# Altium schematic sheet AUDINT003_RevA_MCU.SchDoc(AUDINT003_RevA_MCU)
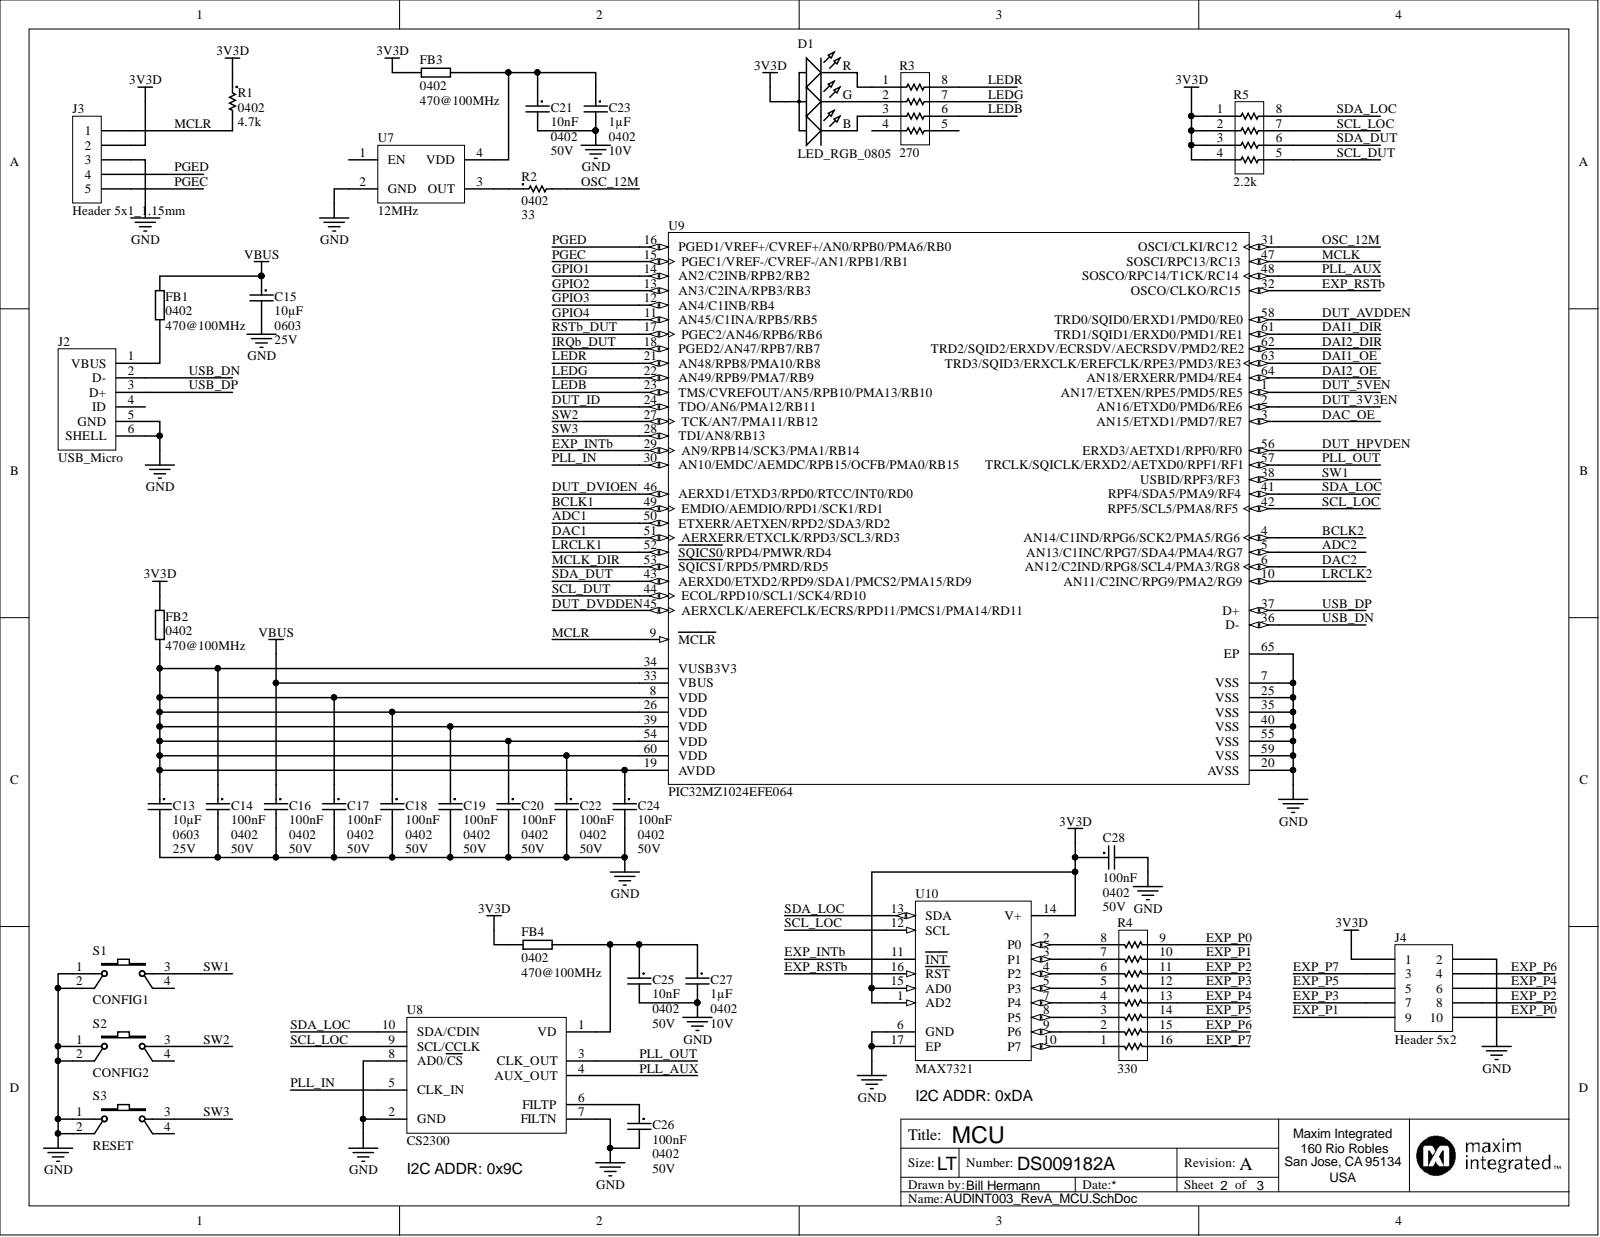
[diagram p0: AUDINT003_RevA_MCU.SchDoc]

## Parts
C13  value 10µF  Voltage: 25V  Package: 0603  [CAPC0603(1608)55_N]  (hidden comment: Capacitor)
C14  value 100nF  Voltage: 50V  Package: 0402  [CAPC0402(1005)60_L]  (hidden comment: Capacitor)
C15  value 10µF  Voltage: 25V  Package: 0603  [CAPC0603(1608)55_N]  (hidden comment: Capacitor)
C16  value 100nF  Voltage: 50V  Package: 0402  [CAPC0402(1005)60_L]  (hidden comment: Capacitor)
C17  value 100nF  Voltage: 50V  Package: 0402  [CAPC0402(1005)60_L]  (hidden comment: Capacitor)
C18  value 100nF  Voltage: 50V  Package: 0402  [CAPC0402(1005)60_L]  (hidden comment: Capacitor)
C19  value 100nF  Voltage: 50V  Package: 0402  [CAPC0402(1005)60_L]  (hidden comment: Capacitor)
C20  value 100nF  Voltage: 50V  Package: 0402  [CAPC0402(1005)60_L]  (hidden comment: Capacitor)
C21  value 10nF  Voltage: 50V  Package: 0402  [CAPC0402(1005)60_L]  (hidden comment: Capacitor)
C22  value 100nF  Voltage: 50V  Package: 0402  [CAPC0402(1005)60_L]  (hidden comment: Capacitor)
C23  value 1µF  Voltage: 10V  Package: 0402  [CAPC0402(1005)60_L]  (hidden comment: Capacitor)
C24  value 100nF  Voltage: 50V  Package: 0402  [CAPC0402(1005)60_L]  (hidden comment: Capacitor)
C25  value 10nF  Voltage: 50V  Package: 0402  [CAPC0402(1005)60_L]  (hidden comment: Capacitor)
C26  value 100nF  Voltage: 50V  Package: 0402  [CAPC0402(1005)60_L]  (hidden comment: Capacitor)
C27  value 1µF  Voltage: 10V  Package: 0402  [CAPC0402(1005)60_L]  (hidden comment: Capacitor)
C28  value 100nF  Package: 0402  [CAPC0402(1005)60_L]  (hidden comment: Capacitor)
D1  LED_RGB_0805  [LED_RGB_0805]
FB1  value 470@100MHz  Package: 0402  [RESC0402(1005)_N]  (hidden comment: BEAD1)
FB2  value 470@100MHz  Package: 0402  [RESC0402(1005)_N]  (hidden comment: BEAD1)
FB3  value 470@100MHz  Package: 0402  [RESC0402(1005)_N]  (hidden comment: BEAD1)
FB4  value 470@100MHz  Package: 0402  [RESC0402(1005)_N]  (hidden comment: BEAD1)
J2  USB_Micro  [10118192-0001LF]
J3  Header 5x1_1.15mm  [MOLEX_53047-0510]
J4  Header 5x2  [HEADER_5x2_POS_100MIL]
R1  value 4.7k  Package: 0402  [RESC0402(1005)_L]  (hidden comment: Res / 4.7kΩ / 1% / 0402)
R2  value 33  Package: 0402  [RESC0402(1005)_L]  (hidden comment: Res / 33Ω / 1% / 0402)
R3  value 270  [741X083]  (hidden comment: RES_270_ARRAY4)
R4  value 330  [741X163]  (hidden comment: RES_330_ARRAY8)
R5  value 2.2k  [741X083]  (hidden comment: RES_2k2_ARRAY4)
S1  CONFIG1  [PTS810_TACTSWITCH]
S2  CONFIG2  [PTS810_TACTSWITCH]
S3  RESET  [PTS810_TACTSWITCH]
U7  Frequency: 12MHz  [OSC_2x1p6]  (hidden comment: OSC_12M_2x1p6)
U8  CS2300  [DGS10_N]
U9  PIC32MZ1024EFE064  [MCHP-QFN-MR64-7150X7150TP_V]  (value not drawn)
U10  MAX7321  [T1633+4_N]

## Nets
3V3D: C28.1 D1.4 FB2.1 FB3.1 FB4.1 J3.2 J4.1 R1.1 R5.1 R5.2 R5.3 R5.4 U10.1(AD2) U10.14(V+) U10.15(AD0)
GND: C13.2 C14.2 C15.2 C16.2 C17.2 C18.2 C19.2 C20.2 C21.2 C22.2 C23.2 C24.2 C25.2 C26.2 C27.2 C28.2 J2.5(GND) J2.6(SHELL) J3.3 J4.2 S1.1 S1.2 S2.1 S2.2 S3.1 S3.2 U7.2(GND) U8.2(GND) U8.7(FILTN) U8.8(AD0/CS) U9.7(VSS) U9.20(AVSS) U9.25(VSS) U9.35(VSS) U9.40(VSS) U9.55(VSS) U9.59(VSS) U9.65(EP) U10.6(GND) U10.17(EP)
VBUS: C15.1 C16.1 FB1.1 U9.33(VBUS)
ADC1: U9.50(ETXERR/AETXEN/RPD2/SDA3/RD2)
ADC2: U9.5(AN13/C1INC/RPG7/SDA4/PMA4/RG7)
BCLK1: U9.49(EMDIO/AEMDIO/RPD1/SCK1/RD1)
BCLK2: U9.4(AN14/C1IND/RPG6/SCK2/PMA5/RG6)
DAC1: U9.51(AERXERR/ETXCLK/RPD3/SCL3/RD3)
DAC2: U9.6(AN12/C2IND/RPG8/SCL4/PMA3/RG8)
DAC_OE: U9.3(AN15/ETXD1/PMD7/RE7)
DAI1_DIR: U9.61(TRD1/SQID1/ERXD0/PMD1/RE1)
DAI1_OE: U9.63(TRD3/SQID3/ERXCLK/EREFCLK/RPE3/PMD3/RE3)
DAI2_DIR: U9.62(TRD2/SQID2/ERXDV/ECRSDV/AECRSDV/PMD2/RE2)
DAI2_OE: U9.64(AN18/ERXERR/PMD4/RE4)
DUT_3V3EN: U9.2(AN16/ETXD0/PMD6/RE6)
DUT_5VEN: U9.1(AN17/ETXEN/RPE5/PMD5/RE5)
DUT_AVDDEN: U9.58(TRD0/SQID0/ERXD1/PMD0/RE0)
DUT_DVDDEN: U9.45(AERXCLK/AEREFCLK/ECRS/RPD11/PMCS1/PMA14/RD11)
DUT_DVIOEN: U9.46(AERXD1/ETXD3/RPD0/RTCC/INT0/RD0)
DUT_HPVDEN: U9.56(ERXD3/AETXD1/RPF0/RF0)
DUT_ID: U9.24(TDO/AN6/PMA12/RB11)
EXP_INTb: U9.29(AN9/RPB14/SCK3/PMA1/RB14) U10.11(INT)
EXP_P0: J4.10 R4.9
EXP_P1: J4.9 R4.10
EXP_P2: J4.8 R4.11
EXP_P3: J4.7 R4.12
EXP_P4: J4.6 R4.13
EXP_P5: J4.5 R4.14
EXP_P6: J4.4 R4.15
EXP_P7: J4.3 R4.16
EXP_RSTb: U9.32(OSCO/CLKO/RC15) U10.16(RST)
GPIO1: U9.14(AN2/C2INB/RPB2/RB2)
GPIO2: U9.13(AN3/C2INA/RPB3/RB3)
GPIO3: U9.12(AN4/C1INB/RB4)
GPIO4: U9.11(AN45/C1INA/RPB5/RB5)
IRQb_DUT: U9.18(PGED2/AN47/RPB7/RB7)
LEDB: R3.6 U9.23(TMS/CVREFOUT/AN5/RPB10/PMA13/RB10)
LEDG: R3.7 U9.22(AN49/RPB9/PMA7/RB9)
LEDR: R3.8 U9.21(AN48/RPB8/PMA10/RB8)
LRCLK1: U9.52(SQICS0/RPD4/PMWR/RD4)
LRCLK2: U9.10(AN11/C2INC/RPG9/PMA2/RG9)
MCLK: U9.47(SOSCI/RPC13/RC13)
MCLK_DIR: U9.53(SQICS1/RPD5/PMRD/RD5)
MCLR: J3.1 R1.2 U9.9(MCLR)
OSC_12M: R2.2 U9.31(OSCI/CLKI/RC12)
PGEC: J3.5 U9.15(PGEC1/VREF-/CVREF-/AN1/RPB1/RB1)
PGED: J3.4 U9.16(PGED1/VREF+/CVREF+/AN0/RPB0/PMA6/RB0)
PLL_AUX: U8.4(AUX_OUT) U9.48(SOSCO/RPC14/T1CK/RC14)
PLL_IN: U8.5(CLK_IN) U9.30(AN10/EMDC/AEMDC/RPB15/OCFB/PMA0/RB15)
PLL_OUT: U8.3(CLK_OUT) U9.57(TRCLK/SQICLK/ERXD2/AETXD0/RPF1/RF1)
RSTb_DUT: U9.17(PGEC2/AN46/RPB6/RB6)
SCL_DUT: R5.5 U9.44(ECOL/RPD10/SCL1/SCK4/RD10)
SCL_LOC: R5.7 U8.9(SCL/CCLK) U9.42(RPF5/SCL5/PMA8/RF5) U10.12(SCL)
SDA_DUT: R5.6 U9.43(AERXD0/ETXD2/RPD9/SDA1/PMCS2/PMA15/RD9)
SDA_LOC: R5.8 U8.10(SDA/CDIN) U9.41(RPF4/SDA5/PMA9/RF4) U10.13(SDA)
SW1: S1.3 U9.38(USBID/RPF3/RF3)
SW2: S2.3 U9.27(TCK/AN7/PMA11/RB12)
SW3: S3.3 U9.28(TDI/AN8/RB13)
USB_DN: J2.2(D-) U9.36(D-)
USB_DP: J2.3(D+) U9.37(D+)
NetC13_1: C13.1 C14.1 C17.1 C18.1 C19.1 C20.1 C22.1 C24.1 FB2.2 U9.8(VDD) U9.19(AVDD) U9.26(VDD) U9.34(VUSB3V3) U9.39(VDD) U9.54(VDD) U9.60(VDD)
NetC21_1: C21.1 C23.1 FB3.2 U7.4(VDD)
NetC25_1: C25.1 C27.1 FB4.2 U8.1(VD)
NetC26_1: C26.1 U8.6(FILTP)
NetD1_1: D1.1 R3.1
NetD1_2: D1.2 R3.2
NetD1_3: D1.3 R3.3
NetFB1_2: FB1.2 J2.1(VBUS)
NetR2_1: R2.1 U7.3(OUT)
NetR4_1: R4.1 U10.10(P7)
NetR4_2: R4.2 U10.9(P6)
NetR4_3: R4.3 U10.8(P5)
NetR4_4: R4.4 U10.7(P4)
NetR4_5: R4.5 U10.5(P3)
NetR4_6: R4.6 U10.4(P2)
NetR4_7: R4.7 U10.3(P1)
NetR4_8: R4.8 U10.2(P0)

Not connected: J2 4(ID); R3 4 5; S1 4; S2 4; S3 4; U7 1(EN)

## Library data of the parts
C13 = CAP_10µF_25V_0603: Capacitor / Ceramic / 10µF / 25V / X5R / 0603; Manufacturer PN: GRM188R61E106MA73D; Manufacturer: Murata; Tolerance: 20%; Simulation: CAP; Library Name: AUDINT002.SchLib; Dielectric: X5R; Component Kind: Standard
C14 C16-C20 C22 C24 C26 C28 = CAP_100nF_50V_0402: Capacitor / Ceramic / 100nF / 50V / X5R / 0402; Manufacturer PN: UMK105BJ104KV-F; Manufacturer: Taiyo Yuden; Tolerance: 10%; Simulation: CAP; Mouser: 963-UMK105BJ104KV-F; Library Name: AUDINT002.SchLib; Dielectric: X5R; Component Kind: Standard
  C28: Voltage: 50V
C15 = Capacitor: Manufacturer PN: GRM188R61E106MA73D; Manufacturer: Murata; Tolerance: 20%; Dielectric: X5R; Simulation: CAP; Library Name: AUDINT002.SchLib; Description: Capacitor; Component Kind: Standard
C21 C25 = CAP_10nF_50V_0402: Capacitor / Ceramic / 10nF / 50V / X7R / 0603; Manufacturer PN: GRM155R71H103KA88D; Manufacturer: Murata; Tolerance: 10%; Simulation: CAP; Mouser: 81-GRM155R71H103KA8D; Library Name: AUDINT002.SchLib; DigiKey: 490-4516-1-ND; Dielectric: X7R; Component Kind: Standard
C23 C27 = CAP_1uF_10V_0402: Capacitor / Ceramic / 1µF / 10V / X5R / 0402; Manufacturer PN: GRM155R61A105KE15D; Manufacturer: Murata; Tolerance: 10%; Simulation: CAP; Mouser: 81-GRM155R61A105KE15; Library Name: AUDINT002.SchLib; DigiKey: 490-3890-1-ND; Dielectric: X5R; Component Kind: Standard
D1 = LED_RGB_0805: LED / RGB / 0805; Manufacturer PN: 150080M153000; Manufacturer: Wurth; Package: 0805; Mouser: 710-150080M153000; Library Name: AUDINT002.SchLib; DigiKey: 732-11392-1-ND; Component Kind: Standard
FB1-FB4 = FB_470_0402: Ferrite Bead / 470Ω / 0402; Manufacturer PN: BLM15PX471SN1D; Manufacturer: Murata; Tolerance: 25%; DCR: 0.2; Current: 1A; Mouser: 81-BLM15PX471SN1D; Library Name: AUDINT002.SchLib; DigiKey: 490-10662-1-ND; Component Kind: Standard
J2 = USB_Micro: Micro USB Type B Socket; Manufacturer: Amphenol FCI; Mouser: 649-10118192-0001LF; Manufacturer PN: 10118192-0001LF; Library Name: AUDINT002.SchLib; DigiKey: 609-4613-1-ND; Component Kind: Standard
J3 = Header 5x1_1.15mm: 5x1 Position Header, 1.15mm Pitch, Shrouded; Manufacturer PN: 53047-0510; Manufacturer: Molex; Mouser: 538-53047-0510; Library Name: AUDINT002.SchLib; DigiKey: WM1734-ND; Component Kind: Standard
J4 = Header 5x2: Manufacturer PN: PRPC005DAAN-RC; Manufacturer: Sullins; Library Name: AUDINT002.SchLib; DigiKey: S2011EC-05-ND; Component Kind: Standard
R1 = RES_4k7_0402: Resistor / Thick Film / 4.7kΩ / 1% / 0402; Manufacturer PN: RC0402FR-074K7L; Manufacturer: Yageo; Power: 1/16W; Tolerance: 1%; Simulation: RESISTOR; Mouser: 603-RC0402FR-074K7L; Library Name: AUDINT002.SchLib; DigiKey: 311-4.7KLRCT-ND; Component Kind: Standard
R2 = RES_33_0402: Resistor / Thick Film / 33Ω / 1% / 0402; Manufacturer PN: AC0402FR-0733RL; Manufacturer: Yageo; Power: 1/16W; Tolerance: 1%; Simulation: RESISTOR; Mouser: 603-AC0402FR-0733RL; Library Name: AUDINT002.SchLib; DigiKey: YAG3479CT-ND; Component Kind: Standard
R3 = RES_270_ARRAY4: Resistor Array / Thick Film / 270Ω / 5% / 0402x4; Manufacturer PN: EXB-N8V271JX; Manufacturer: Panasonic; Power: 50mW; Tolerance: 5%; Package: 0402x4; Mouser: 667-EXB-N8V271JX; Library Name: AUDINT002.SchLib; DigiKey: Y10271CT-ND; Component Kind: Standard
R4 = RES_330_ARRAY8: Resistor Array / Thick Film / 330Ω / 0402x8; Manufacturer PN: EXB-2HV331JV; Manufacturer: Panasonic; Tolerance: 5%; Power: 1/16W; Package: 0402x8; Mouser: 667-EXB-2HV331JV; Library Name: AUDINT002.SchLib; DigiKey: Y1331CT-ND; Component Kind: Standard
R5 = RES_2k2_ARRAY4: Resistor Array / Thick Film / 2.2kΩ / 5% / 0402x4; Manufacturer PN: EXB-N8V222JX; Manufacturer: Panasonic; Power: 50mW; Tolerance: 5%; Package: 0402x4; Mouser: 667-EXB-N8V222JX; Library Name: AUDINT002.SchLib; DigiKey: Y10222CT-ND; Component Kind: Standard
S1-S3 = SW_TACTILE: Miniture Tact Switch; Manufacturer PN: PTS810 SJM 250 SMTR LFS; Manufacturer: C&K; Mouser: 611-PTS810SJM250SMTR; Library Name: AUDINT002.SchLib; Component Kind: Standard
U7 = OSC_12M_2x1p6: Crystal Oscillator / 12MHz / 1.8-3.3V / 2.0 x 1.6mm; Manufacturer PN: ECS-2016MV-120-BN-TR; Manufacturer: ECS; Package: 2x1.6; Mouser: 520-2016MV-120-BNT; Library Name: AUDINT002.SchLib; DigiKey: XC2799CT-ND; Component Kind: Standard
U8 = CS2300: Fractional-N Clock Multiplier; Manufacturer PN: CS2300CP-CZZ; Manufacturer: Cirrus Logic; Package: TSSOP10; Mouser: 777-CS2300CP-CZZ; Library Name: AUDINT002.SchLib; Component Kind: Standard
U9 = PIC32MZ2048EFG064: 32-bit, 200MHz Flash MCU with HS USB & Ethernet; Manufacturer PN: PIC32MZ1024EFE064-I/MR; Manufacturer: Microchip Technology; Package: QFN64; Mouser: 579-MZ1024EFE064IMR; Library Name: AUDINT002.SchLib; DigiKey: PIC32MZ1024EFE064-I/MR-ND; Component Kind: Standard
U10 = MAX7321: I2C Port Expander with 8 Open-Drain I/Os; Manufacturer PN: MAX7321ATE+; Manufacturer: Maxim; Package: TQFN16; Library Name: AUDINT002.SchLib; Component Kind: Standard
